# Altium schematic sheet S7CAS-PLEIADES-CARTE-MERE_P02_FLASH.SchDoc
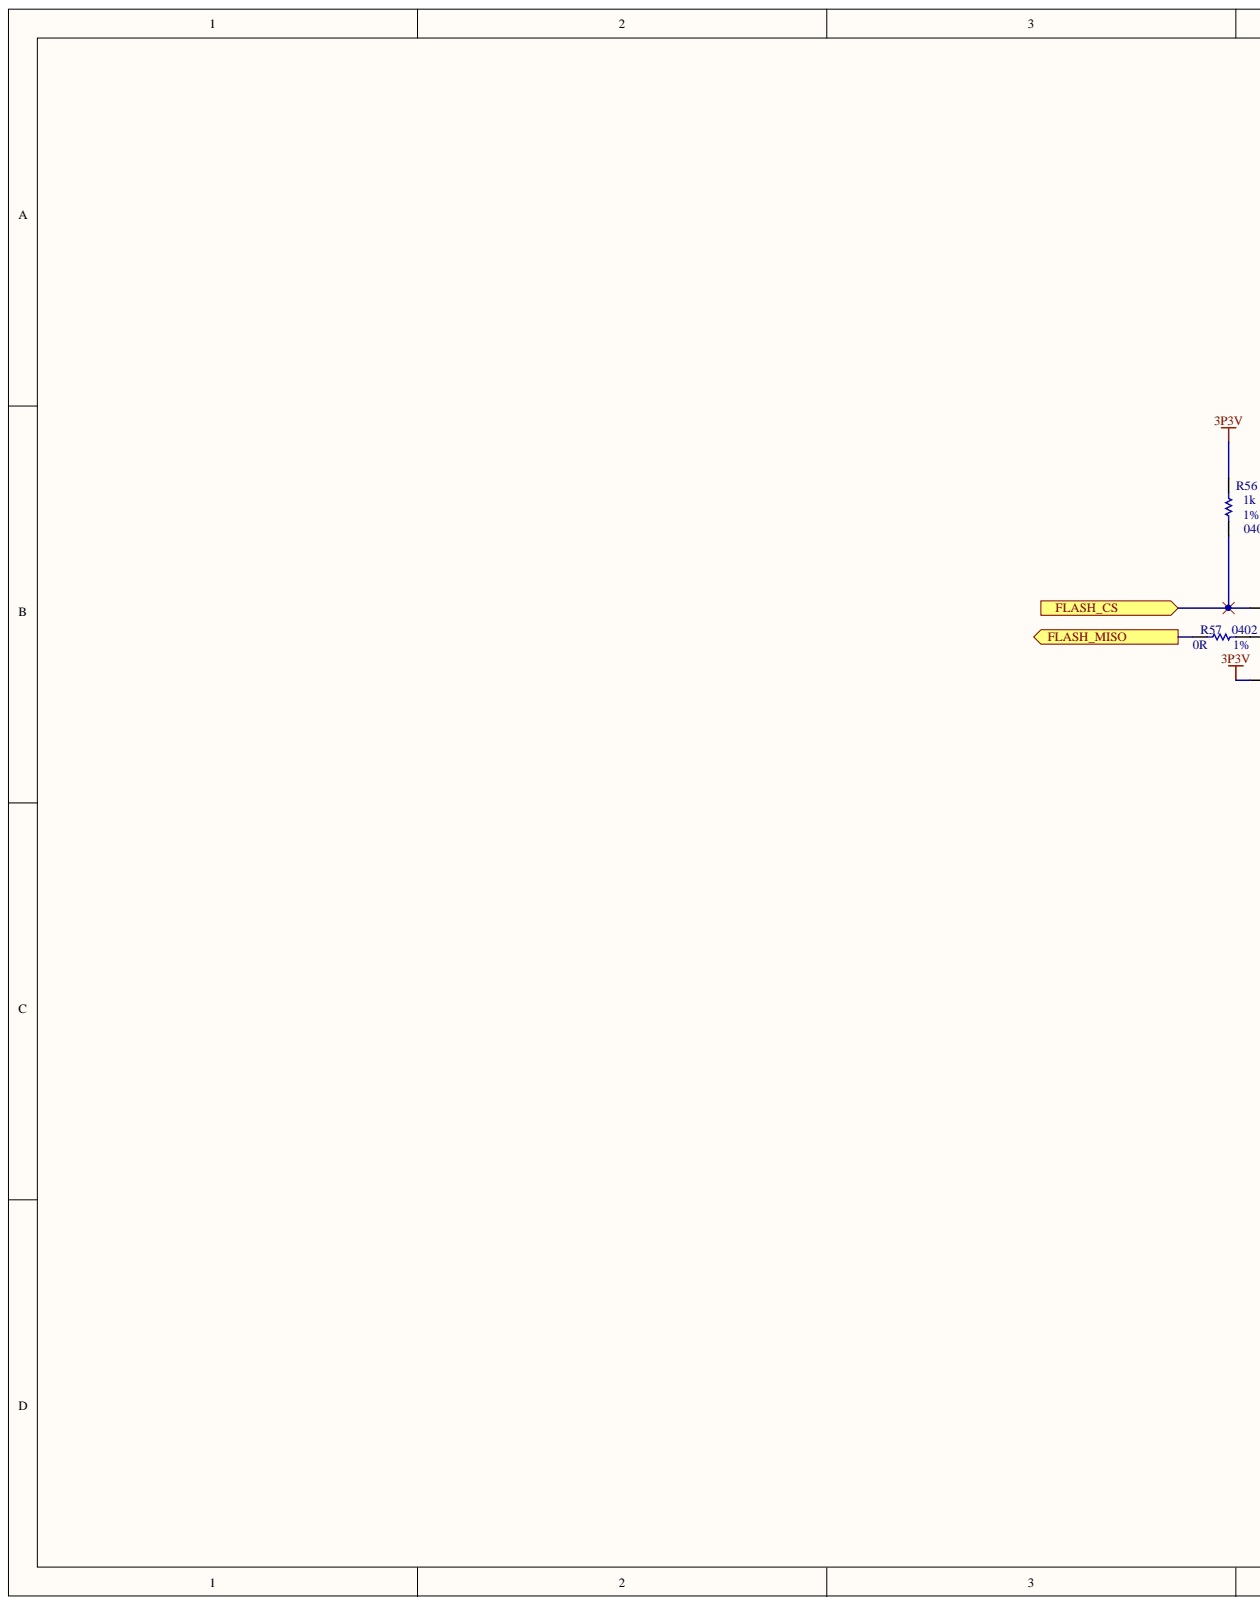
[diagram p0: S7CAS-PLEIADES-CARTE-MERE_P02_FLASH.SchDoc, left]
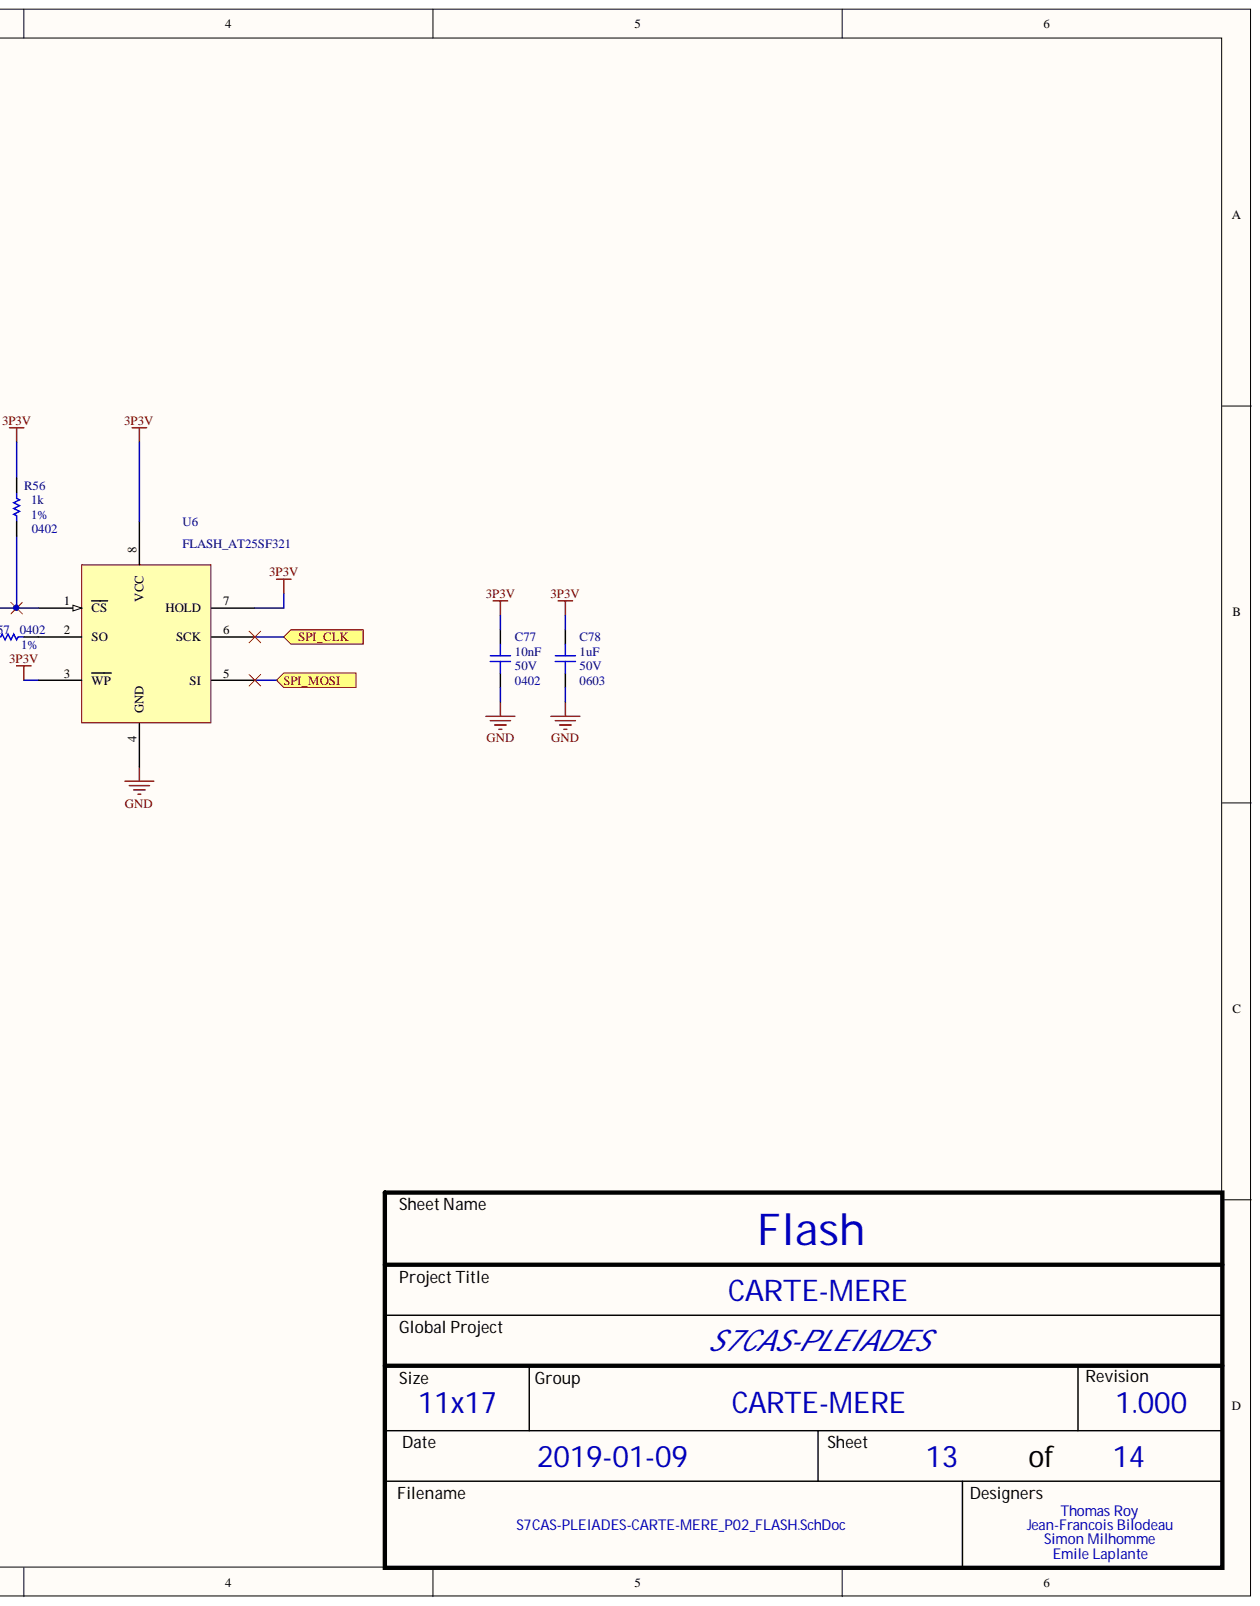
[diagram p1: S7CAS-PLEIADES-CARTE-MERE_P02_FLASH.SchDoc, right]

## Parts
C77  value 10nF  Package: 0402  Voltage: 50V  [CAP_0402]  (hidden comment: CAP - 0603)
C78  value 1uF  Package: 0603  Voltage: 50V  [CAP_0603]  (hidden comment: CAP - 0603)
R56  value 1k  [RES_0402]  (hidden comment: RES - 0402)
R57  value 0R  Package: 0402  Precision: 1%  [RES_0402]  (hidden comment: RES - 0402)
U6  FLASH_AT25SF321  [8-SOIC]

## Ports
FLASH_CS, FLASH_MISO, SPI_CLK, SPI_MOSI

## Nets
3P3V: C77.2 C78.2 R56.2 U6.3(WP) U6.7(HOLD) U6.8(VCC)
GND: C77.1 C78.1 U6.4(GND)
FLASH_CS: R56.1 U6.1(CS) ; port FLASH_CS
FLASH_MISO: R57.2 ; port FLASH_MISO
SPI_CLK: U6.6(SCK) ; port SPI_CLK
SPI_MOSI: U6.5(SI) ; port SPI_MOSI
NetR57_1: R57.1 U6.2(SO)

## Library data of the parts
C77 C78 = CAP - 0603: Precision: 10%; Component Kind: Standard; Description: CAP - 0603; Library Name: S7CAS_Lib2019_REV1_00.IntLib; CreatedBy: Jonathan Bouchard
R56 = RES - 0402: Power: 1/16W; Precision: 1%; Voltage: 50V; Component Kind: Standard; Description: RES - 0402; Library Name: S7CAS_Lib2019_REV1_00.IntLib; Package: 0402; CreatedBy: Jonathan Bouchard
R57 = RES - 0402: Power: 1/16W; Voltage: 50V; Component Kind: Standard; Description: RES - 0402; Library Name: S7CAS_Lib2019_REV1_00.IntLib; CreatedBy: Jonathan Bouchard
U6 = FLASH_AT25SF321: Component Kind: Standard; Library Name: lib_Emile.SchLib
